# Altium schematic sheet BMS Carrier - Battery Relay Controls.SchDoc("BMS Carrier - Battery Relay Controls")
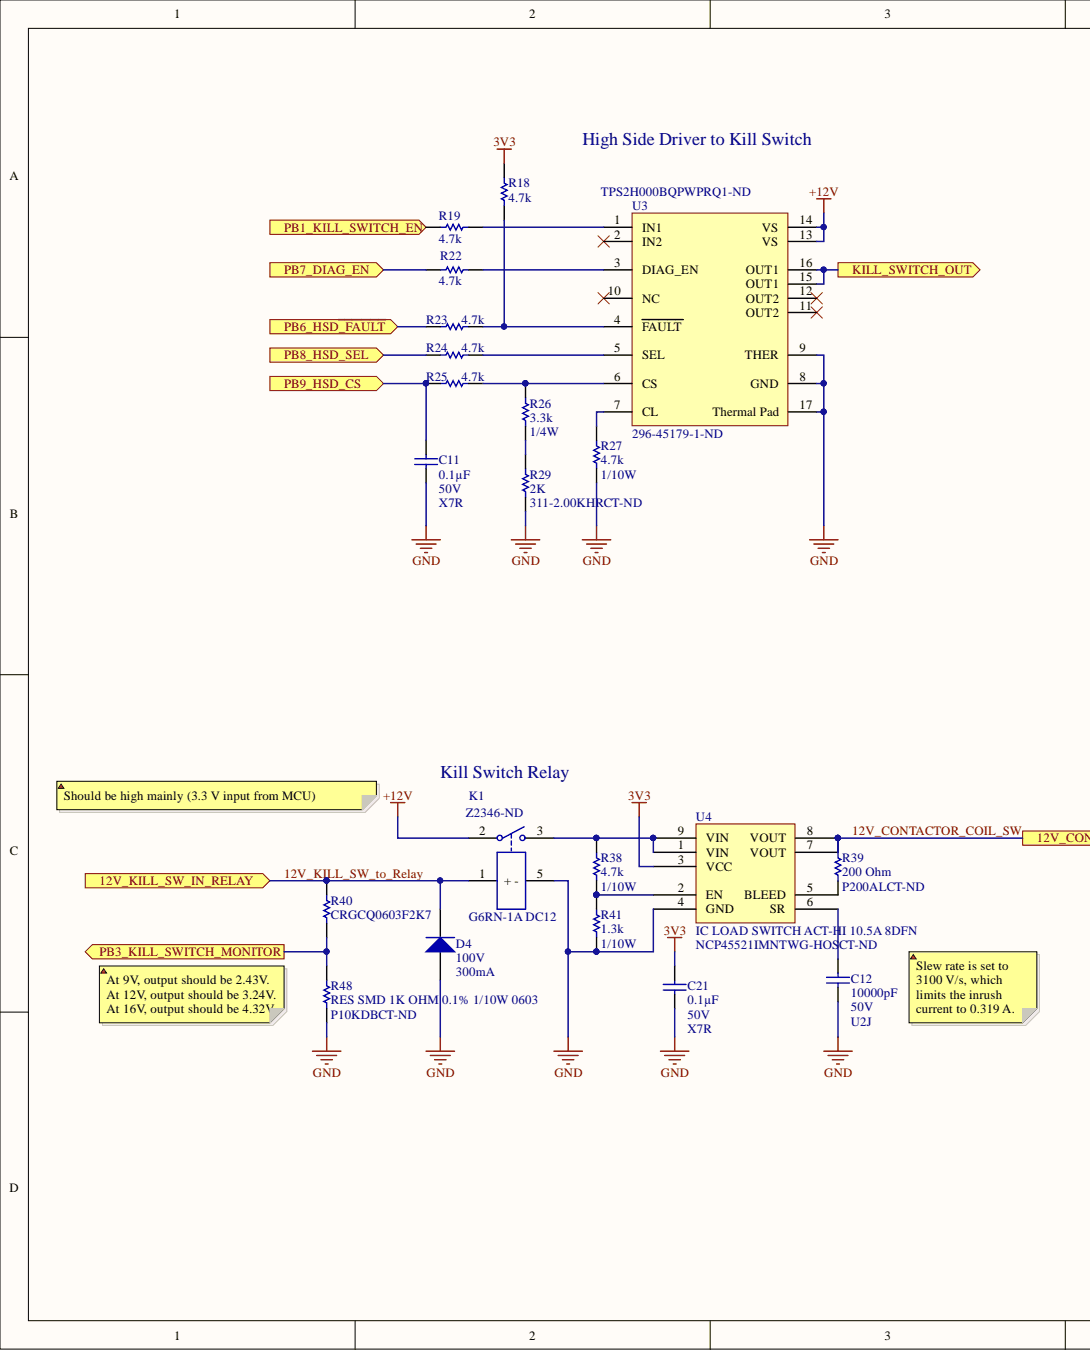
[diagram p0: BMS Carrier - Battery Relay Controls.SchDoc, left]
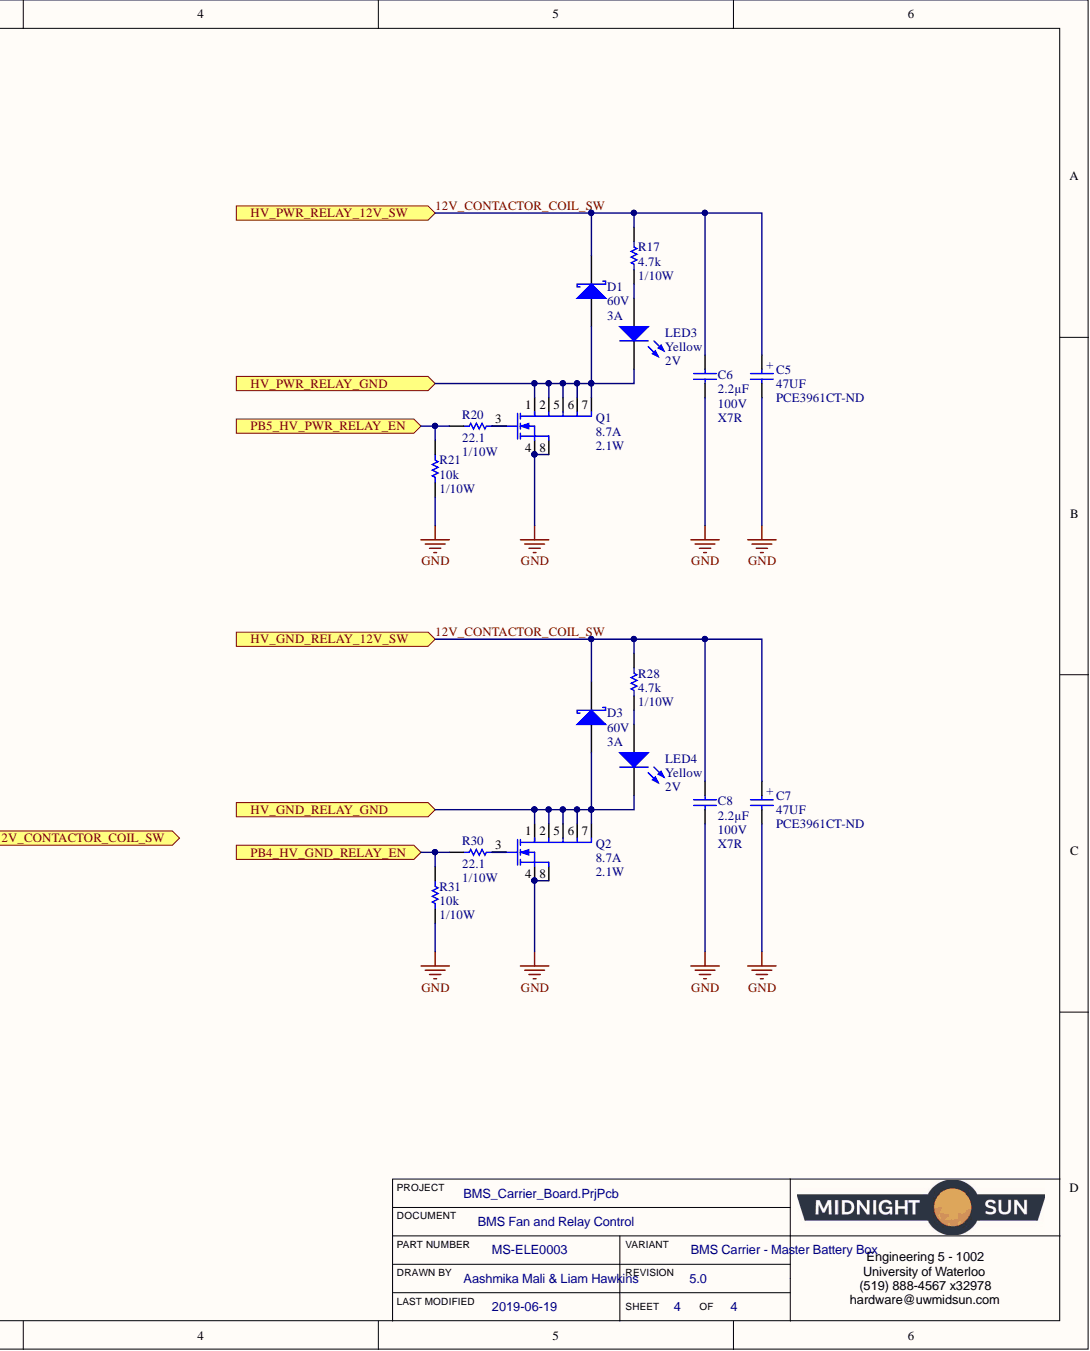
[diagram p1: BMS Carrier - Battery Relay Controls.SchDoc, right]

## Parts
C5  47UF  Supplier Part Number 1: PCE3961CT-ND  [CAP ALUM 6.3MM RADIAL SMD]
C6  Voltage: 100V  Temperature Coefficient: X7R  Capacitance: 2.2µF  [CAP, 1206]  (hidden comment: CAP CER 2.2UF 100V ±20% X7R 1206)
C7  47UF  Supplier Part Number 1: PCE3961CT-ND  [CAP ALUM 6.3MM RADIAL SMD]
C8  Voltage: 100V  Temperature Coefficient: X7R  Capacitance: 2.2µF  [CAP, 1206]  (hidden comment: CAP CER 2.2UF 100V ±20% X7R 1206)
C11  Voltage: 50V  Temperature Coefficient: X7R  Capacitance: 0.1µF  [CAP, 0603]  (hidden comment: CAP CER 0.1UF 50V 10% X7R 0603)
C12  Voltage: 50V  Temperature Coefficient: U2J  Capacitance: 10000pF  [CAP, 0603]  (hidden comment: CAP CER 10nF 50V 5% X7R 0603)
C21  Voltage: 50V  Temperature Coefficient: X7R  Capacitance: 0.1µF  [CAP, 0603]  (hidden comment: CAP CER 0.1UF 50V 10% X7R 0603)
D1  Voltage - DC Reverse (Vr) (Max): 60V  Current - Average Rectified (Io): 3A  [Diode, SMA]  (hidden comment: DIODE SCHOTTKY 60V 3A SMA)
D3  Voltage - DC Reverse (Vr) (Max): 60V  Current - Average Rectified (Io): 3A  [Diode, SMA]  (hidden comment: DIODE SCHOTTKY 60V 3A SMA)
D4  Max Repetitive Reverse Voltage (Vrrm): 100V  Forward Current: 300mA  [DIODE GEN PURP 100V 300MA SOD123]  (hidden comment: DIODE GEN PURP 100V 300MA SOD123)
K1  G6RN-1A DC12  Supplier Part Number 2: Z2346-ND  [RELAY SPST 8A 12VDC]
LED3  Voltage - Forward (Vf) (Typ): 2V  Color: Yellow  [LED_0603]  (hidden comment: LED YELLOW CLEAR 2.1V 0603)
LED4  Voltage - Forward (Vf) (Typ): 2V  Color: Yellow  [LED_0603]  (hidden comment: LED YELLOW CLEAR 2.1V 0603)
Q1  Power Dissipation (Max): 2.1W  Current - Continuous Drain (Id) @ 25°C: 8.7A  [MOSFET N-CH 30V 12A 2mmx2mm PQFN]  (hidden comment: MOSFET N-CH 30V 8.7A 2.1W 6-PQFN (2x2))
Q2  Power Dissipation (Max): 2.1W  Current - Continuous Drain (Id) @ 25°C: 8.7A  [MOSFET N-CH 30V 12A 2mmx2mm PQFN]  (hidden comment: MOSFET N-CH 30V 8.7A 2.1W 6-PQFN (2x2))
R17  Resistance (Ohms): 4.7k  Power (Watts): 1/10W  [RES, 0603]  (hidden comment: RES 4.7K OHM 1% 1/10W 0603)
R18  Resistance (Ohms): 4.7k  [RES, 0603]  (hidden comment: RES 10K OHM 1% 1/10W 0603)
R19  Resistance (Ohms): 4.7k  [RES, 0603]  (hidden comment: RES 4.7K OHM 1% 1/10W 0603)
R20  Resistance: 22.1  [RES, 0603]  (hidden comment: RES 22.1 OHM 1% 1/10W 0603)
R21  Resistance: 10k  Power: 1/10W  [RES, 0603]  (hidden comment: RES 10K OHM 1% 1/10W 0603)
R22  Resistance (Ohms): 4.7k  [RES, 0603]  (hidden comment: RES 4.7K OHM 1% 1/10W 0603)
R23  Resistance (Ohms): 4.7k  [RES, 0603]  (hidden comment: RES 4.7K OHM 1% 1/10W 0603)
R24  Resistance (Ohms): 4.7k  [RES, 0603]  (hidden comment: RES 4.7K OHM 1% 1/10W 0603)
R25  Resistance (Ohms): 4.7k  [RES, 0603]  (hidden comment: RES 4.7K OHM 1% 1/10W 0603)
R26  Resistance: 3.3k  Power: 1/4W  [RES, 0603]  (hidden comment: RES 3.3K OHM 1% 1/4W 0603)
R27  Resistance (Ohms): 4.7k  Power (Watts): 1/10W  [RES, 0603]  (hidden comment: RES 4.7K OHM 1% 1/10W 0603)
R28  Resistance (Ohms): 4.7k  Power (Watts): 1/10W  [RES, 0603]  (hidden comment: RES 4.7K OHM 1% 1/10W 0603)
R29  2K  Supplier Part Number 1: 311-2.00KHRCT-ND  [RES, 0603]
R30  Resistance: 22.1  [RES, 0603]  (hidden comment: RES 22.1 OHM 1% 1/10W 0603)
R31  Resistance: 10k  Power: 1/10W  [RES, 0603]  (hidden comment: RES 10K OHM 1% 1/10W 0603)
R38  Resistance (Ohms): 4.7k  Power (Watts): 1/10W  [RES, 0603]  (hidden comment: RES 4.7K OHM 1% 1/10W 0603)
R39  200 Ohm  Supplier Part Number 1: P200ALCT-ND  [RES 1206]
R40  Manufacturer Part Number: CRGCQ0603F2K7  [RES, 0603]  (hidden comment: RES 2.7K OHM 1% 1/10W 0603)
R41  Resistance: 1.3k  Power Rating: 1/10W  [RES, 0603]  (hidden comment: RES 1.3K OHM 1% 1/10W 0603)
R48  RES SMD 1K OHM 0.1% 1/10W 0603  Supplier Part Number 1: P10KDBCT-ND  [RES, 0603]
U3  296-45179-1-ND  Supplier Part Number 1: TPS2H000BQPWPRQ1-ND  [IC HSD Dual-Channel TSOP65P640X120_HS-17N]
U4  IC LOAD SWITCH ACT-HI 10.5A 8DFN  Output Current: 10.5A  [IC LOAD SWITCH ACT-HI 10.5A 8DFN]

## Ports
12V_CONTACTOR_COIL_SW, 12V_KILL_SW_IN_RELAY, HV_GND_RELAY_12V_SW, HV_GND_RELAY_GND, HV_PWR_RELAY_12V_SW, HV_PWR_RELAY_GND, KILL_SWITCH_OUT, PB1_KILL_SWITCH_EN, PB3_KILL_SWITCH_MONITOR, PB4_HV_GND_RELAY_EN, PB5_HV_PWR_RELAY_EN, PB6_HSD~{_FAULT}, PB7_DIAG_EN, PB8_HSD_SEL, PB9_HSD_CS

## Nets
3V3: C21.1 R18.2 U4.3(VCC)
+12V: K1.2 U3.13(VS) U3.14(VS)
GND: C5.2 C6.2 C7.2 C8.2 C11.2 C12.2 C21.2 D4.1 K1.5(-) Q1.4 Q1.8 Q2.4 Q2.8 R21.2 R27.2 R29.2 R31.2 R41.2 R48.1 U3.8(GND) U3.9(THER) U3.17(Thermal_Pad) U4.4(GND)
12V_CONTACTOR_COIL_SW: C5.1 C6.1 C7.1 C8.1 D1.2 D3.2 R17.1 R28.1 R39.1 U4.7(VOUT) U4.8(VOUT) ; port 12V_CONTACTOR_COIL_SW, port HV_GND_RELAY_12V_SW, port HV_PWR_RELAY_12V_SW
12V_KILL_SW_to_Relay: D4.2 K1.1(+) R40.1 ; port 12V_KILL_SW_IN_RELAY
HV_GND_RELAY_GND: D3.1 LED4.2 Q2.1 Q2.2 Q2.5 Q2.6 Q2.7 ; port HV_GND_RELAY_GND
HV_PWR_RELAY_GND: D1.1 LED3.2 Q1.1 Q1.2 Q1.5 Q1.6 Q1.7 ; port HV_PWR_RELAY_GND
KILL_SWITCH_OUT: U3.15(OUT1) U3.16(OUT1) ; port KILL_SWITCH_OUT
PB1_KILL_SWITCH_EN: R19.1 ; port PB1_KILL_SWITCH_EN
PB3_KILL_SWITCH_MONITOR: R40.2 R48.2 ; port PB3_KILL_SWITCH_MONITOR
PB4_HV_GND_RELAY_EN: R30.1 R31.1 ; port PB4_HV_GND_RELAY_EN
PB5_HV_PWR_RELAY_EN: R20.1 R21.1 ; port PB5_HV_PWR_RELAY_EN
PB6_HSD~{_FAULT}: R23.1 ; port PB6_HSD~{_FAULT}
PB7_DIAG_EN: R22.1 ; port PB7_DIAG_EN
PB8_HSD_SEL: R24.1 ; port PB8_HSD_SEL
PB9_HSD_CS: C11.1 R25.1 ; port PB9_HSD_CS
NetC12_1: C12.1 U4.6(SR)
NetK1_3: K1.3 R38.1 U4.1(VIN) U4.9(VIN)
NetLED3_1: LED3.1 R17.2
NetLED4_1: LED4.1 R28.2
NetQ1_3: Q1.3 R20.2
NetQ2_3: Q2.3 R30.2
NetR18_1: R18.1 R23.2 U3.4(FAULT)
NetR19_2: R19.2 U3.1(IN1)
NetR22_2: R22.2 U3.3(DIAG_EN)
NetR24_2: R24.2 U3.5(SEL)
NetR25_2: R25.2 R26.1 U3.6(CS)
NetR26_2: R26.2 R29.1
NetR27_1: R27.1 U3.7(CL)
NetR38_2: R38.2 R41.1 U4.2(EN)
NetR39_2: R39.2 U4.5(BLEED)

Not connected: U3 2(IN2) 10(NC) 11(OUT2) 12(OUT2)

## Library data of the parts
C5 C7 = CAP ALUM 47UF 20% 35V SMD: 47µF 35V Aluminum Electrolytic Capacitors Radial, Can - SMD 1000 Hrs @ 85°C; Manufacturer Part Number: EEE-1VA470WP; Manufacturer: Panasonic; Tolerance: 20%; Ripple Current (AC): 70mA; Life (Hours): 1000Hour; Voltage Rating (DC): 35V; Voltage Rating: 35V; Termination: SMD/SMT; Supplier 1: Digi-Key; Stock 1: 2820; Ripple Current: 70mA; REACH SVHC: Unknown; Radiation Hardening: No; Pricing 1: 1=0.38, 10=0.263, 100=0.1579, 500=0.11846 (USD); Packaging: Tape and Reel; Package Quantity: 1; Mount: Surface Mount,PCB; Min Operating Temperature: -40°C; Max Operating Temperature: 85°C; Length: 5.5mm; Lead Free: Lead Free; Height - Seated (Max): 0.213inch; Height: 5.4mm; Diameter: 6.3mm; Contact Plating: Tin; ComponentLink6URL: https://datasheet.ciiva.com/30206/11aba0000ce2-30206253.pdf; ComponentLink6Description: https://datasheet.ciiva.com/30206/11aba0000ce2-30206253.pdf; ComponentLink5URL: https://datasheet.ciiva.com/16507/aba0000ce2-16507965.pdf; ComponentLink5Description: https://datasheet.ciiva.com/16507/aba0000ce2-16507965.pdf; ComponentLink4URL: https://datasheet.ciiva.com/15165/getdatasheetpartid-86239-15165868.pdf; ComponentLink4Description: https://datasheet.ciiva.com/15165/getdatasheetpartid-86239-15165868.pdf; ComponentLink3URL: https://datasheet.ciiva.com/14598/70255710-14598937.pdf; ComponentLink3Description: https://datasheet.ciiva.com/14598/70255710-14598937.pdf; ComponentLink2URL: https://datasheet.ciiva.com/2983/aba0000ce2-2983927.pdf; ComponentLink2Description: https://datasheet.ciiva.com/2983/aba0000ce2-2983927.pdf; ComponentLink1URL: https://datasheet.ciiva.com/2983/aba0000ce2-2983926.pdf; ComponentLink1Description: https://datasheet.ciiva.com/2983/aba0000ce2-2983926.pdf; Component Kind: Standard; Case/Package: Radial; Capacitance: 47uF; Library Name: Schematic Diagrams.SchLib
C6 C8 = CAP CER 2.2UF 100V ±20% X7R 1206: 2.2µF ±20% 100V Ceramic Capacitor X7R 1206 (3216 Metric); Manufacturer Part Number 1: GRM31CR72A225MA73L; Manufacturer 1: Murata Electronics North America; Tolerance: ±20%; Thickness (Max): 0.071" (1.80mm); Supplier Part Number 1: 490-12773-1-ND; Supplier 1: Digi-Key; Size / Dimension: 0.126" L x 0.063" W (3.20mm x 1.60mm); Ratings: -; Part Status: Active; Packaging: Cut Tape (CT); Package / Case: 1206 (3216 Metric); Operating Temperature: -55°C ~ 125°C; Mounting Type: Surface Mount, MLCC; Lead Style: -; Lead Spacing: -; Height - Seated (Max): -; ComponentLink1URL: http://www.murata.com/~/media/webrenewal/support/library/catalog/products/capacitor/mlcc/c02e.ashx; ComponentLink1Description: http://www.murata.com/~/media/webrenewal/support/library/catalog/products/capacitor/mlcc/c02e.ashx; Component Kind: Standard; Library Name: Schematic Diagrams.SchLib
C11 C21 = CAP CER 0.1UF 50V 10% X7R 0603: 0.10µF ±10% 50V Ceramic Capacitor X7R 0603 (1608 Metric); Manufacturer Part Number 1: 06035C104KAT2A; Manufacturer 1: AVX Corporation; Tolerance: ±10%; Thickness (Max): 0.035" (0.90mm); Supplier Part Number 1: 478-5052-1-ND; Supplier 1: Digi-Key; Size / Dimension: 0.063" L x 0.032" W (1.60mm x 0.81mm); Ratings: -; Part Status: Active; Packaging: Cut Tape (CT); Package / Case: 0603 (1608 Metric); Operating Temperature: -55°C ~ 125°C; Mounting Type: Surface Mount, MLCC; Lead Style: -; Lead Spacing: -; Height - Seated (Max): -; ComponentLink1URL: http://datasheets.avx.com/X7RDielectric.pdf; ComponentLink1Description: http://datasheets.avx.com/X7RDielectric.pdf; Component Kind: Standard; Library Name: Schematic Diagrams.SchLib
C12 = CAP CER 10nF 50V 5% X7R 0603: 10000pF ±5% 50V Ceramic Capacitor U2J 0603 (1608 Metric); Manufacturer Part Number 1: C0603C103J5JAC7867; Manufacturer 1: KEMET; Tolerance: ±5%; Thickness (Max): 0.034" (0.87mm); Supplier Part Number 1: 399-13384-1-ND; Supplier 1: Digi-Key; Size / Dimension: 0.063" L x 0.032" W (1.60mm x 0.80mm); Ratings: -; Part Status: Active; Packaging: Cut Tape (CT); Package / Case: 0603 (1608 Metric); Operating Temperature: -55°C ~ 125°C; Mounting Type: Surface Mount, MLCC; Lead Style: -; Lead Spacing: -; Height - Seated (Max): -; Failure Rate: -; ComponentLink1URL: http://www.kemet.com/docfinder?Partnumber=C0603C103J5JAC7867; ComponentLink1Description: http://www.kemet.com/docfinder?Partnumber=C0603C103J5JAC7867; Component Kind: Standard; Library Name: Schematic Diagrams.SchLib
D1 D3 = DIODE SCHOTTKY 60V 3A SMA: Diode Schottky 60V 3A Surface Mount SMA; Manufacturer Part Number 1: B360A-13-F; Manufacturer 1: Diodes Incorporated; Voltage - Forward (Vf) (Max) @ If: 700mV @ 3A; Speed: Fast Recovery =< 500ns, > 200mA (Io); Current - Reverse Leakage @ Vr: 500µA @ 60V; Capacitance @ Vr, F: 200pF @ 4V, 1MHz; Supplier Part Number 1: B360A-FDICT-ND; Supplier Device Package: SMA; Supplier 1: Digi-Key; Part Status: Active; Packaging: Cut Tape (CT); Package / Case: DO-214AC, SMA; Operating Temperature - Junction: -55°C ~ 150°C; Mounting Type: Surface Mount; Diode Type: Schottky; ComponentLink1URL: https://www.diodes.com/assets/Datasheets/ds30891.pdf; ComponentLink1Description: https://www.diodes.com/assets/Datasheets/ds30891.pdf; Component Kind: Standard; Library Name: Schematic Diagrams.SchLib
D4 = DIODE GEN PURP 100V 300MA SOD123: Diode Standard 100V 300mA (DC) Surface Mount SOD-123; Manufacturer Part Number: 1N4148WQ-7-F; Manufacturer: Diodes Zetex; Reverse Recovery Time: 4ns; Peak Reverse Current: 50uA; Peak Non-Repetitive Surge Current: 2A; Max Power Dissipation: 400mW; Forward Voltage: 1.25V; Element Configuration: Single; Weight: 0.000353oz; Supplier Part Number 1: 1N4148WQ-7-FDICT-ND; Supplier 1: Digi-Key; Stock 1: 229; Recovery Time: 4ns; Pricing 1: 1=0.22, 10=0.196, 100=0.107, 500=0.0658, 1000=0.0449 (USD); Packaging: Tape and Reel; Package Quantity: 3000; Number of Pins: 2; Mount: Surface Mount; Min Operating Temperature: -65°C; Max Surge Current: 2A; Max Operating Temperature: 150°C; Lead Free: Lead Free; Contact Plating: Tin,Matte; ComponentLink3URL: http://www.mouser.com/ds/2/115/ds30086-318161.pdf; ComponentLink3Description: http://www.mouser.com/ds/2/115/ds30086-318161.pdf; ComponentLink2URL: http://www.diodes.com/datasheets/ds30086.pdf; ComponentLink2Description: http://www.diodes.com/datasheets/ds30086.pdf; ComponentLink1URL: http://components.arrow.com/part/dataview/0323_07206809; ComponentLink1Description: http://components.arrow.com/part/dataview/0323_07206809; Component Kind: Standard; Case/Package: SOD-123-2; Library Name: Schematic Diagrams.SchLib
K1 = RELAY SPST 12V 8A OMRON: Omron Relay SPST (1 Form A) Through Hole; Switching Voltage: 250VAC, 30VDC - Max; Operate Time: 15ms; Coil Voltage: 12V; Coil Type: Non Latching; Coil Power: 220 mW; Supplier 2: Digi-Key; Supplier 1: Digi-Key; Manufacturer Part Number: G6RN-1A DC12; Contact Form: SPST; ComponentLink1URL: https://omronfs.omron.com/en_US/ecb/products/pdf/en-g6rn.pdf; ComponentLink1Description: Datasheet; Component Kind: Standard; Library Name: Schematic Diagrams.SchLib
LED3 LED4 = LED YELLOW CLEAR 2.1V 0603: Yellow 590nm LED Indication - Discrete 2V 0603 (1608 Metric); Manufacturer Part Number: 150060YS75000; Manufacturer: Wurth Electronics Inc.; Wavelength - Peak: 595nm; Viewing Angle: 140°; Millicandela Rating: 120mcd; Lens Style/Size: Rectangle with Flat Top, 1.10mm x 0.70mm; Lens Color: Colorless; Current - Test: 20mA; Wavelength - Dominant: 590nm; Supplier Part Number 1: 732-4981-1-ND; Supplier Device Package: 0603; Supplier 1: Digi-Key; Stock 1: 10523; Size / Dimension: 1.60mm L x 0.80mm W; RoHS: RoHS Compliant; Pricing 1: 1=0.21, 100=0.1617, 500=0.13964 (CAD); Part Status: Active; Packaging: Cut Tape (CT); Package / Case: 0603 (1608 Metric); Mounting Type: Surface Mount; Lens Transparency: Clear; Height (Max): 0.80mm; Features: -; ComponentLink1URL: http://katalog.we-online.de/led/datasheet/150060YS75000.pdf; ComponentLink1Description: http://katalog.we-online.de/led/datasheet/150060YS75000.pdf; Component Kind: Standard; Category: Optoelectronics; Library Name: Schematic Diagrams.SchLib
Q1 Q2 = MOSFET N-CH 30V 8.7A 2.1W 6-PQFN (2x2): Manufacturer Part Number 1: IRLHS6342TRPBF; Manufacturer 1: Infineon Technologies; Vgs(th) (Max) @ Id: 1.1V @ 10µA; Vgs (Max): ±12V; Rds On (Max) @ Id, Vgs: 15.5 mOhm @ 8.5A, 4.5V; Input Capacitance (Ciss) (Max) @ Vds: 1019pF @ 25V; Gate Charge (Qg) (Max) @ Vgs: 11nC @ 4.5V; FET Type: N-Channel; Drive Voltage (Max Rds On,  Min Rds On): 2.5V, 4.5V; Description 1: MOSFET N-CH 30V 8.7A 2X2 PQFN; Technology: MOSFET (Metal Oxide); Supplier Part Number 1: IRLHS6342TRPBFCT-ND; Supplier Device Package: 6-PQFN (2x2); Supplier 1: Digi-Key; Stock 1: 9984; RoHS: RoHS Compliant; Pricing 1: 1=0.79, 10=0.685, 100=0.5118, 500=0.4022, 1000=0.31079 (CAD); Part Status: Active; Packaging: Cut Tape (CT); Package / Case: 6-PowerVDFN; Operating Temperature: -55°C ~ 150°C (TJ); Mounting Type: Surface Mount; FET Feature: -; Drain to Source Voltage (Vdss): 30V; ComponentLink1URL: http://www.infineon.com/dgdl/irlhs6342pbf.pdf?fileId=5546d462533600a401535663b52625ad; ComponentLink1Description: http://www.infineon.com/dgdl/irlhs6342pbf.pdf?fileId=5546d462533600a401535663b52625ad; Component Kind: Standard; Category: Discrete Semiconductor Products; Library Name: Schematic Diagrams.SchLib
R17-R19 R22-R25 R27 R28 R38 = RES 4.7K OHM 1% 1/10W 0603: 4.7k Ohm ±1% 0.1W, 1/10W Chip Resistor 0603 (1608 Metric) Moisture Resistant Thick Film; Manufacturer Part Number 1: RC0603FR-074K7L; Manufacturer 1: Yageo; Temperature Coefficient: ±100ppm/°C; Description 1: RES SMD 4.7K OHM 1% 1/10W 0603; Tolerance: ±1%; Supplier Part Number 1: 311-4.70KHRCT-ND; Supplier Device Package: 0603; Supplier 1: Digi-Key; Stock 1: 3487566; Size / Dimension: 0.063" L x 0.031" W (1.60mm x 0.80mm); RoHS: RoHS Compliant; Pricing 1: 1=0.16, 10=0.022, 100=0.0089, 1000=0.00402, 2500=0.00349 (CAD); Part Status: Active; Packaging: Cut Tape (CT); Package / Case: 0603 (1608 Metric); Operating Temperature: -55°C ~ 155°C; Height - Seated (Max): 0.022" (0.55mm); Features: Moisture Resistant; Failure Rate: -; Composition: Thick Film; ComponentLink1URL: http://www.yageo.com/documents/recent/PYu-RC_Group_51_RoHS_L_7.pdf; ComponentLink1Description: http://www.yageo.com/documents/recent/PYu-RC_Group_51_RoHS_L_7.pdf; Component Kind: Standard; Category: Resistors; Library Name: Schematic Diagrams.SchLib
  R18 R19 R22-R25: Power (Watts): 1/10W
R20 R30 = RES 22.1 OHM 1% 1/10W 0603: 22.1 Ohm ±1% 0.1W, 1/10W Chip Resistor 0603 (1608 Metric) Moisture Resistant Thick Film; Manufacturer Part Number 1: RC0603FR-0722R1L; Manufacturer 1: Yageo; Temperature Coefficient: ±100ppm/°C; Tolerance: ±1%; Supplier Part Number 1: 311-22.1HRCT-ND; Supplier Device Package: 0603; Supplier 1: Digi-Key; Size / Dimension: 0.063" L x 0.031" W (1.60mm x 0.80mm); Power: 1/10W; Part Status: Active; Packaging: Cut Tape (CT); Package / Case: 0603 (1608 Metric); Operating Temperature: -55°C ~ 155°C; Height - Seated (Max): 0.022" (0.55mm); Failure Rate: -; Composition: Thick Film; ComponentLink1URL: http://www.yageo.com/documents/recent/PYu-RC_Group_51_RoHS_L_7.pdf; ComponentLink1Description: http://www.yageo.com/documents/recent/PYu-RC_Group_51_RoHS_L_7.pdf; Component Kind: Standard; Library Name: Schematic Diagrams.SchLib
R21 R31 = RES 10K OHM 1% 1/10W 0603: RES SMD 10K OHM 1% 1/10W 0603; Manufacturer Part Number 1: RC0603FR-0710KL; Manufacturer 1: Yageo; Temperature Coefficient: ±100ppm/°C; Tolerance: ±1%; Supplier Part Number 1: 311-10.0KHRCT-ND; Supplier Device Package: 0603; Supplier 1: Digi-Key; Size / Dimension: 0.063" L x 0.031" W (1.60mm x 0.80mm); Part Status: Active; Packaging: Cut Tape (CT); Package / Case: 0603 (1608 Metric); Operating Temperature: -55°C ~ 155°C; Height - Seated (Max): 0.022" (0.55mm); Failure Rate: -; Composition: Thick Film; ComponentLink1URL: http://www.yageo.com/documents/recent/PYu-RC_Group_51_RoHS_L_7.pdf; ComponentLink1Description: http://www.yageo.com/documents/recent/PYu-RC_Group_51_RoHS_L_7.pdf; Component Kind: Standard; Library Name: Schematic Diagrams.SchLib
R26 = RES 3.3K OHM 1% 1/4W 0603: 3.3k Ohm ±1% 0.25W, 1/4W Chip Resistor 0603 (1608 Metric) Automotive AEC-Q200, Pulse Withstanding Thick Film; Manufacturer Part Number 1: ERJ-PA3F3301V; Manufacturer 1: Panasonic Electronic Components; Temperature Coefficient: ±100ppm/°C; Tolerance: ±1%; Supplier Part Number 1: P3.3KBYCT-ND; Supplier Device Package: 0603; Supplier 1: Digi-Key; Size / Dimension: 0.063" L x 0.031" W (1.60mm x 0.80mm); Part Status: Active; Packaging: Cut Tape (CT); Package / Case: 0603 (1608 Metric); Operating Temperature: -55°C ~ 155°C; Height - Seated (Max): 0.022" (0.55mm); Failure Rate: -; Composition: Thick Film; ComponentLink1URL: https://industrial.panasonic.com/cdbs/www-data/pdf/RDO0000/AOA0000C291.pdf; ComponentLink1Description: https://industrial.panasonic.com/cdbs/www-data/pdf/RDO0000/AOA0000C291.pdf; Component Kind: Standard; Library Name: Schematic Diagrams.SchLib
R29 = RES 2K OHM 1% 1/10W 0603: 2k Ohm ±1% 0.1W, 1/10W Chip Resistor 0603 (1608 Metric) Moisture Resistant Thick Film; Manufacturer Part Number: RC0603FR-072KL; Manufacturer: Yageo; Temperature Coefficient: ±100ppm/°C; Tolerance: ±1%; Supplier Device Package: 0603; Supplier 1: Digi-Key; Stock 1: 1750701; Size / Dimension: 0.063" L x 0.031" W (1.60mm x 0.80mm); RoHS: RoHS Compliant; Resistance (Ohms): 2k; Pricing 1: 1=0.15, 10=0.023, 100=0.0093, 1000=0.00415, 2500=0.0036 (CAD); Power (Watts): 0.1W, 1/10W; Part Status: Active; Packaging: Cut Tape (CT); Package / Case: 0603 (1608 Metric); Operating Temperature: -55°C ~ 155°C; Height - Seated (Max): 0.022" (0.55mm); Features: Moisture Resistant; Failure Rate: -; Composition: Thick Film; ComponentLink1URL: http://www.yageo.com/documents/recent/PYu-RC_Group_51_RoHS_L_7.pdf; ComponentLink1Description: http://www.yageo.com/documents/recent/PYu-RC_Group_51_RoHS_L_7.pdf; Component Kind: Standard; Category: Resistors; Library Name: Schematic Diagrams.SchLib
R39 = RES 200 OHM 5% 2/3W 1206: 200 Ohms ±5% 0.667W, 2/3W Chip Resistor 1206 (3216 Metric) Automotive AEC-Q200, Pulse Withstanding Thick Film; Manufacturer Part Number: ERJ-P08J201V; Manufacturer: Panasonic; Voltage Rating (DC): 200V; Voltage Rating: 500V; Temperature Coefficient: 200ppm/°C; Resistance: 200R; Power Rating: 660mW; Max Power Dissipation: 667mW; Width: 1.6mm; Tolerance: 5%; Termination: SMD/SMT; Supplier 1: Digi-Key; Stock 1: 32165; Radiation Hardening: No; Pricing 1: 1=0.16, 10=0.137, 100=0.0534, 1000=0.02237, 2500=0.02051 (USD); Packaging: Tape and Reel; Package Quantity: 1; Number of Terminations: 2; Mount: Surface Mount; Min Operating Temperature: -55°C; Max Operating Temperature: 155°C; Length: 3.2mm; Lead Free: Contains Lead; Height: 0.028inch; Contact Plating: Tin; Composition: Thick Film; ComponentLink4URL: https://datasheet.ciiva.com/30201/aoa0000ce4-30201485.pdf; ComponentLink4Description: https://datasheet.ciiva.com/30201/aoa0000ce4-30201485.pdf; ComponentLink3URL: https://datasheet.ciiva.com/9184/aoa0000ce4-9184603.pdf; ComponentLink3Description: https://datasheet.ciiva.com/9184/aoa0000ce4-9184603.pdf; ComponentLink2URL: https://datasheet.ciiva.com/9184/aoa0000ce4-9184599.pdf; ComponentLink2Description: https://datasheet.ciiva.com/9184/aoa0000ce4-9184599.pdf; ComponentLink1URL: https://datasheet.ciiva.com/17207/aoa0000ce4-17207029.pdf; ComponentLink1Description: https://datasheet.ciiva.com/17207/aoa0000ce4-17207029.pdf; Component Kind: Standard; Case Code (Metric): 3216; Case Code (Imperial): 1206; Case/Package: 1206; Library Name: Schematic Diagrams.SchLib
R40 = RES 2.7K OHM 1% 1/10W 0603: 2.7 kOhms ±1% 0.1W, 1/10W Chip Resistor 0603 (1608 Metric) Automotive AEC-Q200, Moisture Resistant Thick Film; Manufacturer: TE Connectivity; Supplier Part Number 1: A129693CT-ND; Supplier 1: Digi-Key; Stock 1: 137187; RoHS: No; Pricing 1: 1 = 0.10000, 10 = 0.03300, 100 = 0.01320, 1000 = 0.00595, 2500 = 0.00516 (USD); ComponentLink2URL: https://www.te.com/commerce/DocumentDelivery/DDEController?Action=srchrtrv&DocNm=1773204-3&DocType=DS&DocLang=English&src-supplier=Digi-Key; ComponentLink2Description: https://www.te.com/commerce/DocumentDelivery/DDEController?Action=srchrtrv&DocNm=1773204-3&DocType=DS&DocLang=English&src-supplier=Digi-Key; ComponentLink1URL: http://www.te.com/commerce/DocumentDelivery/DDEController?Action=srchrtrv&DocNm=1773204-3&DocType=DS&DocLang=English&src-supplier=Digi-Key; ComponentLink1Description: http://www.te.com/commerce/DocumentDelivery/DDEController?Action=srchrtrv&DocNm=1773204-3&DocType=DS&DocLang=English&src-supplier=Digi-Key; Component Kind: Standard; Library Name: Schematic Diagrams.SchLib
R41 = RES 1.3K OHM 1% 1/10W 0603: 1.3 kOhms ±1% 0.1W, 1/10W Chip Resistor 0603 (1608 Metric) Moisture Resistant Thick Film; Manufacturer Part Number: RC0603FR-071K3L; Manufacturer: Yageo; Working Voltage: 75V; Voltage Rating (DC): 50V; Temperature Coefficient: 100ppm/°C; Width: 800um; Voltage Rating: 50V; Tolerance: 1%; Termination: SMD/SMT; Supplier Part Number 1: 311-1.30KHRCT-ND; Supplier 1: Digi-Key; Stock 1: 57984; Pricing 1: 1=0.1, 10=0.015, 100=0.006, 1000=0.0027, 2500=0.0023 (USD); Packaging: Tape and Reel; Number of Terminations: 2; Min Operating Temperature: -55°C; Military Standard: Not; Max Operating Temperature: 155°C; Length: 1.6mm; Lead Free: Lead Free; Depth: 0.8mm; Composition: Thick Film; ComponentLink4URL: http://www.yageo.com/documents/recent/PYu-RC0603_51_RoHS_L_v5.pdf; ComponentLink4Description: http://www.yageo.com/documents/recent/PYu-RC0603_51_RoHS_L_v5.pdf; ComponentLink3URL: http://www.yageo.com.tw/exep/pages/download/literatures/PYu-R_INT-thick_7.pdf; ComponentLink3Description: http://www.yageo.com.tw/exep/pages/download/literatures/PYu-R_INT-thick_7.pdf; ComponentLink2URL: http://www.mouser.com/ds/2/447/RC0603-272842.pdf; ComponentLink2Description: http://www.mouser.com/ds/2/447/RC0603-272842.pdf; ComponentLink1URL: http://components.arrow.com/part/dataview/0323_00200771; ComponentLink1Description: http://components.arrow.com/part/dataview/0323_00200771; Component Kind: Standard; Case Code (Metric): 1608; Case Code (Imperial): 0603; Case/Package: 0603; Library Name: Schematic Diagrams.SchLib
R48 = RES SMD 1K OHM 0.1% 1/10W 0603: 1 kOhms ±0.1% 0.1W, 1/10W Chip Resistor 0603 (1608 Metric) Automotive AEC-Q200 Thin Film; Manufacturer Part Number: ERA3AEB103V; Manufacturer: Panasonic; Voltage Rating (DC): 75V; Temperature Coefficient: 25ppm/°C; Resistance: 10kR; Power Rating: 100mW; Width: 0.8mm; Voltage Rating: 75V; Tolerance: 0.1%; Termination: SMD/SMT; Supplier 1: Digi-Key; Stock 1: 2747820; RoHS: Yes; REACH SVHC: No SVHC; Radiation Hardening: No; Pricing 1: 1 = 0.35000, 10 = 0.30000, 100 = 0.11720, 1000 = 0.04906, 2500 = 0.04498 (USD); Packaging: Tape and Reel; Package Quantity: 5000; Number of Terminations: 2; Number of Pins: 2; Mount: Surface Mount; Min Operating Temperature: -55°C; Military Standard: Not; Max Power Dissipation: 100mW; Max Operating Temperature: 155°C; Length: 1.6mm; Lead Free: Lead Free; Height: 0.45mm; Depth: 0.8mm; Contact Plating: Tin; Composition: Metal Film,Thin Film; ComponentLink8URL: http://www.produktinfo.conrad.com/datenblaetter/450000-474999/451250-da-01-en-WIDERSTAND_0603_ERA.pdf; ComponentLink8Description: http://www.produktinfo.conrad.com/datenblaetter/450000-474999/451250-da-01-en-WIDERSTAND_0603_ERA.pdf; ComponentLink7URL: http://www.farnell.com/datasheets/2059650.pdf; ComponentLink7Description: http://www.farnell.com/datasheets/2059650.pdf; ComponentLink6URL: http://uk.rs-online.com/webdocs/148c/0900766b8148c4ab.pdf; ComponentLink6Description: http://uk.rs-online.com/webdocs/148c/0900766b8148c4ab.pdf; ComponentLink5URL: http://www.farnell.com/datasheets/1776116.pdf; ComponentLink5Description: http://www.farnell.com/datasheets/1776116.pdf; ComponentLink4URL: http://www.farnell.com/datasheets/2052875.pdf; ComponentLink4Description: http://www.farnell.com/datasheets/2052875.pdf; ComponentLink3URL: http://industrial.panasonic.com/www-data/pdf/AOA0000/AOA0000CE26.pdf; ComponentLink3Description: http://industrial.panasonic.com/www-data/pdf/AOA0000/AOA0000CE26.pdf; ComponentLink2URL: http://www.farnell.com/datasheets/485442.pdf; ComponentLink2Description: http://www.farnell.com/datasheets/485442.pdf; ComponentLink1URL: https://www.digikey.ca/product-detail/en/panasonic-electronic-components/ERA-3AEB102V/P1.0KDBCT-ND/1466052; ComponentLink1Description: Digikey; Component Kind: Standard; Case Code (Metric): 1608; Case Code (Imperial): 0603; Case/Package: 0603; Library Name: Schematic Diagrams.SchLib
U3 = IC HSD Dual-Channel 40V 1KOhm: Supplier Part Number: 296-45179-1-ND; Supplier 1: Digi-Key; Manufacturer Part Number: TPS2H000BQPWPRQ1-ND; Description: IC HSD Dual-Channel 40V 1KOhm; ComponentLink1URL: http://www.ti.com/lit/ds/symlink/tps2h000-q1.pdf; ComponentLink1Description: Datasheet; Component Kind: Standard; Library Name: Schematic Diagrams.SchLib
U4 = IC LOAD SWITCH ACT-HI 10.5A 8DFN: NCP45521IMNTWG-H; Manufacturer: ON Semiconductor; Turn-On Delay Time: 270us; Turn-Off Delay Time: 1.2us; Power Dissipation: 2.5W; Output Configuration: High Side; Operating Supply Voltage: 5.5V; On-State Resistance: 22.5mR; Number of Outputs: 1; Nominal Supply Current: 500uA; Interface: On/Off; Fault Protection: Over Temperature; Supplier Part Number 1: NCP45521IMNTWG-HOSCT-ND; Supplier 1: Digi-Key; RoHS: Yes; REACH SVHC: No SVHC; Radiation Hardening: No; Pricing 1: 1 = 0.87000, 10 = 0.77400, 100 = 0.60360, 500 = 0.49864, 1000 = 0.39366 (USD); Packaging: Tape and Reel; Package Quantity: 3000; Number of Pins: 8; Mount: Surface Mount; Min Operating Temperature: -40°C; Max Supply Current: 10.5A; Max Power Dissipation: 2.5W; Max Output Current: 10.5A; Max Operating Temperature: 85°C; Manufacturer Part Number: NCP45521IMNTWG-H; Lead Free: Lead Free; Halogen Free: Halogen Free; ComponentLink2URL: http://www.onsemi.com/pub/Collateral/NCP45520-D.PDF; ComponentLink2Description: http://www.onsemi.com/pub/Collateral/NCP45520-D.PDF; ComponentLink1URL: http://www.mouser.com/ds/2/308/NCP45520-D-211422.pdf; ComponentLink1Description: http://www.mouser.com/ds/2/308/NCP45520-D-211422.pdf; Component Kind: Standard; Case/Package: DFN; Library Name: Schematic Diagrams.SchLib
